# Altium schematic sheet Sheet3.SchDoc
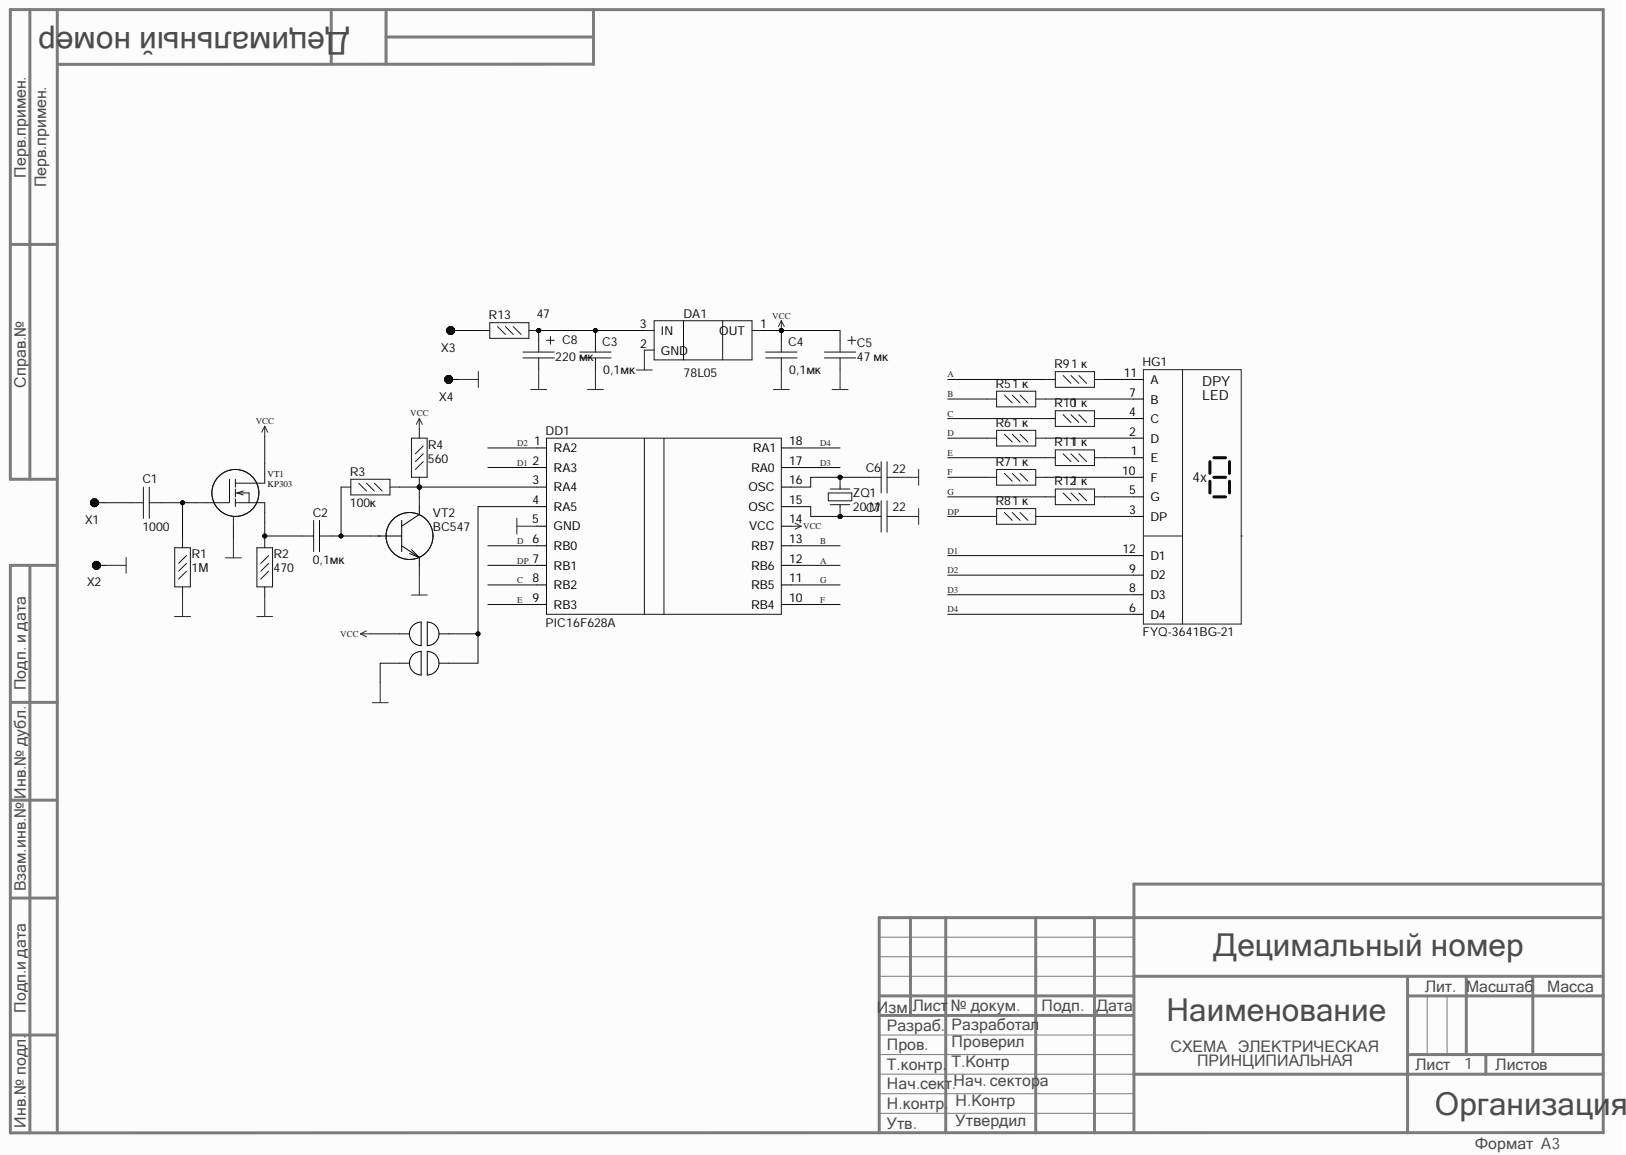
[diagram p0: Sheet3.SchDoc]

## Parts
C1  1000  [К10-17Б]
C2  0,1мк  [К10-17Б]
C3  0,1мк  [К10-17Б]
C4  0,1мк  [К10-17Б]
C5  47 мк  [К50-35]
C6  22  [К10-17Б]
C7  22  [К10-17Б]
C8  220 мк  [К50-35 - 8x11.5]
DA1  78L05  [КТ-26]
DD1  PIC16F628A  [DIP18]
HG1  FYQ-3641BG-21  [FYQ-3641]
R1  1М  [R_C1-4_V]
R2  470  [R_C1-4_V]
R3  100к  [R_C1-4_V]
R4  560  [R_C1-4_V]
R5  1 к  [R_C1-4_V]
R6  1 к  [R_C1-4_V]  (value not drawn)
R7  1 к  [R_C1-4_V]  (value not drawn)
R8  1 к  [R_C1-4_V]  (value not drawn)
R9  1 к  [R_C1-4_V]
R10  1 к  [R_C1-4_V]
R11  1 к  [R_C1-4_V]
R12  1 к  [R_C1-4_V]  (value not drawn)
R13  47  [R_C1-4_V]
VT1  KP303  [KP303]
VT2  BC547  [КТ-26]
X1  КТ  [KT]  (value not drawn)
X2  КТ  [KT]  (value not drawn)
X3  КТ  [KT]  (value not drawn)
X4  КТ  [KT]  (value not drawn)
X5  Solder_jumper  [Solder_jumper]  (value not drawn)
X6  Solder_jumper  [Solder_jumper]  (value not drawn)
ZQ1  20 M  [HC-49]

## Nets
GND: C3.1 C4.1 C5.2 C6.2 C7.2 C8.2 DA1.2 DD1.5(GND) R1.1 R2.1 VT1.3 VT2.3 X2.1 X4.1 X6.1
VCC: C4.2 C5.1 DA1.1 DD1.14(VCC) R4.1 VT1.4 X5.1
A: DD1.12(RB6) R9.2
B: DD1.13(RB7) R5.2
C: DD1.8(RB2) R10.2
D: DD1.6(RB0) R6.2
D1: DD1.2(RA3) HG1.12(D1)
D2: DD1.1(RA2) HG1.9(D2)
D3: DD1.17(RA0) HG1.8(D3)
D4: DD1.18(RA1) HG1.6(D4)
DP: DD1.7(RB1) R8.2
E: DD1.9(RB3) R11.2
F: DD1.10(RB4) R7.2
G: DD1.11(RB5) R12.2
NetC1_1: C1.1 R1.2 VT1.1
NetC1_2: C1.2 X1.1
NetC2_1: C2.1 R3.1 VT2.2
NetC2_2: C2.2 R2.2 VT1.2
NetC3_2: C3.2 C8.1 DA1.3 R13.1
NetC6_1: C6.1 DD1.16(OSC) ZQ1.1
NetC7_1: C7.1 DD1.15(OSC) ZQ1.2
NetDD1_3: DD1.3(RA4) R3.2 R4.2 VT2.1
NetDD1_4: DD1.4(RA5) X5.2 X6.2
NetHG1_1: HG1.1(E) R11.1
NetHG1_2: HG1.2(D) R6.1
NetHG1_3: HG1.3(DP) R8.1
NetHG1_4: HG1.4(C) R10.1
NetHG1_5: HG1.5(G) R12.1
NetHG1_7: HG1.7(B) R5.1
NetHG1_10: HG1.10(F) R7.1
NetHG1_11: HG1.11(A) R9.1
NetR13_2: R13.2 X3.1

## Library data of the parts
C1-C4 C6 C7 = C_К10-17Б: Component Kind: Standard; Library Name: 1. Passive.SchLib
C5 C8 = K50-35: Component Kind: Standard; Library Name: 1. Passive.SchLib
DA1 = 78L06: Component Kind: Standard; Library Name: 2. Chips.SchLib
DD1 = PIC16F628A: Manufacturer: Toshiba; Manufacturer Part Number: TB6600HG(O); Max Power Dissipation: 3.2W; Max Supply Voltage: 42V; Min Supply Voltage: 8V; Motor Type: Stepper; Nominal Supply Current: 1.8-4.2mA; Number Of Outputs: 4; Output Current: 4.5A; Standoff Height: 2.67mm; Switching Frequency: 200kHz; Automotive: No; Category: IC; Component Kind: Standard; ComponentLink2Description: Datasheet; ComponentLink2URL: https://toshiba.semicon-storage.com/info/docget.jsp?did=14683&prodName=TB6600HG; Device Class L1: Integrated Circuits (ICs); Device Class L2: Power Management ICs; Device Class L3: Motor Drivers; Digikey Description: IC MTR DRVR BIPOLAR 8-42V 25HZIP; Height: 19.87mm; Lead Free: Yes; Library Name: 2. Chips.SchLib; Manufacturer 1: Toshiba; Manufacturer Part Number 1: TB6600HG(O); Mouser Description: Motor / Motion / Ignition Controllers & Drivers 2-phase stepping motor Drvr 50V; Octopart: https://octopart.com/tb6600hg%28o%29-toshiba-24612481; Package: HZIP25-P-1.00F; RoHS: TRUE; Temperature: -30°C to +85°C; Temperature Range High: +85°C; Temperature Range Low: -30°C
HG1 = FYQ-3641BG-21: 4-х разр. светод. индикатор, зеленый, (ОА); Component Kind: Standard; Library Name: 8. Other.SchLib
R1-R13 = R_C1-4: Component Kind: Standard; Library Name: 1. Passive.SchLib
ZQ1 = HC-49: Component Kind: Standard; Library Name: 5. Resonarors.SchLib
VT1 = KP303: Component Kind: Standard; Library Name: KP303.SchLib; PCB3D: Model Name
VT2 = BC547: Component Kind: Standard; Library Name: 4. Transistors.SchLib
X1-X4 = КТ: Component Kind: Standard; Library Name: 7. Connectors.SchLib
X5 X6 = Solder_jumper: Component Kind: Standard; Library Name: 11.Other.SchLib
